# Altium schematic sheet DP_UART.SchDoc
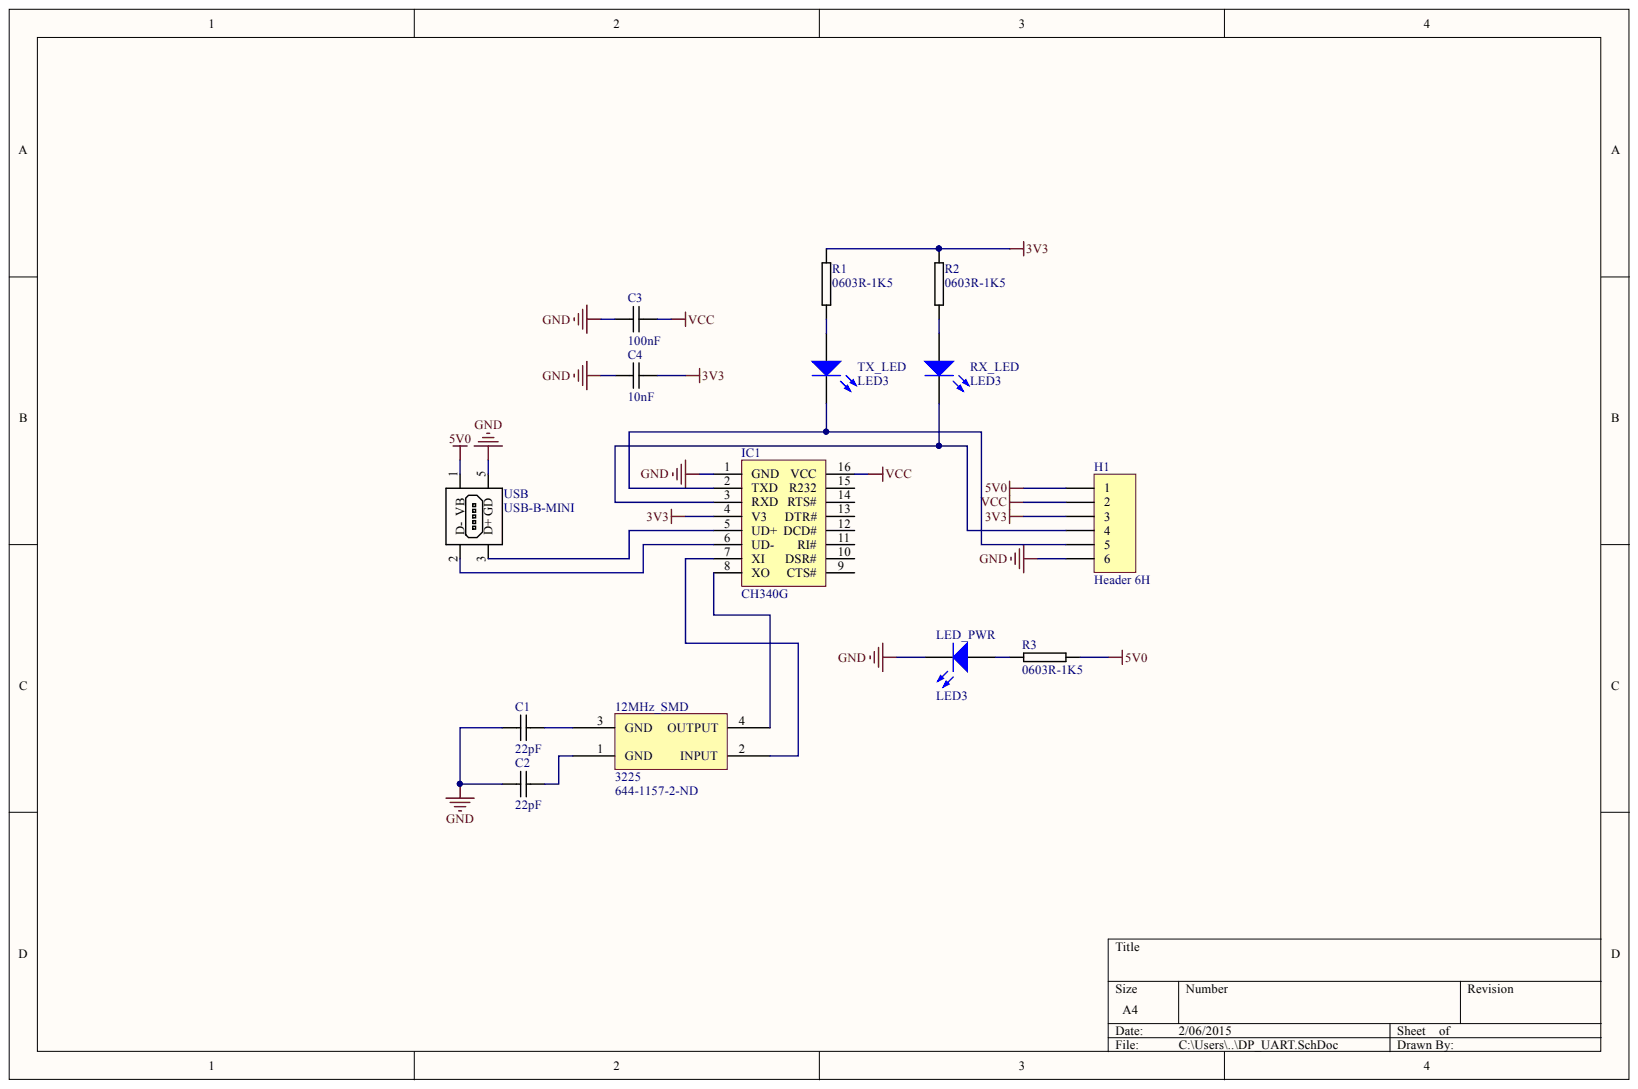
[diagram p0: DP_UART.SchDoc]

## Parts
12MHz_SMD  3225  Supplier Part Number 1: 644-1157-2-ND  [XTAL 3225]
C1  22pF  [0603C-GENERIC]
C2  22pF  [0603C-GENERIC]
C3  100nF  [0603C-GENERIC]
C4  10nF  [0603C-GENERIC]
H1  Header 6H  [HDR1X6H]
IC1  CH340G  [SO16]
LED_PWR  LED3  [3.5X2.8X1.9]
R1  0603R-1K5  [0603R-1k5]
R2  0603R-1K5  [0603R-1k5]
R3  0603R-1K5  [0603R-1k5]
RX_LED  LED3  [3.5X2.8X1.9]
TX_LED  LED3  [3.5X2.8X1.9]
USB  USB-B-MINI  [USB-MINI-B]

## Nets
3V3: C4.2 H1.3 IC1.4(V3) R1.1 R2.1
5V0: H1.1 R3.1 USB.1(VB)
GND: C1.1 C2.1 C3.1 C4.1 H1.6 IC1.1(GND) LED_PWR.2 USB.5(GD)
VCC: C3.2 H1.2 IC1.16(VCC)
Net12MHz_SMD_1: 12MHz_SMD.1(GND) C2.2
Net12MHz_SMD_2: 12MHz_SMD.2(INPUT) IC1.7(XI)
Net12MHz_SMD_3: 12MHz_SMD.3(GND) C1.2
Net12MHz_SMD_4: 12MHz_SMD.4(OUTPUT) IC1.8(XO)
NetH1_4: H1.4 IC1.3(RXD) RX_LED.2
NetH1_5: H1.5 IC1.2(TXD) TX_LED.2
NetIC1_5: IC1.5(UD+) USB.3(D+)
NetIC1_6: IC1.6(UD-) USB.2(D-)
NetLED_PWR_1: LED_PWR.1 R3.2
NetR1_2: R1.2 TX_LED.1
NetR2_2: R2.2 RX_LED.1

Not connected: IC1 9(CTS#) 10(DSR#) 11(RI#) 12(DCD#) 13(DTR#) 14(RTS#) 15(R232)

## Library data of the parts
12MHz_SMD = 3225 Quartz: Component Kind: Standard; Supplier 1: Digi-Key; Library Name: RVA_Reference_Crystal Oscillator_SMD.SchLib
C1 C2 = Passives_Capacitors_0603_22PF-50V-C0G-PAN: CAPACITOR 0603 CERAMIC; Manufacturer: Panasonic - ECG; Manufacturer Part Number: ECJ-1VC1H220J; Reel: C10; Temperature Coefficient: C0G, NP0; Tolerance: ±5%; Voltage - Rated: 50V; Capacitance: 22pF; Category: Capacitors; Component Kind: Standard; Features: General Purpose; Lead Spacing: -; Mounting Type: Surface Mount, MLCC; Operating Temperature: -55°C ~ 125°C; Package / Case: 0603 (1608 metric); Packaging: Tape & Reel (TR); RoHS: RoHS Compliant; Supplier: Digi-Key; Supplier 1: Digi-Key; Supplier Part Number: PCC220ACVTR-ND; Supplier Part Number 1: PCC220ACVTR-ND; Thickness: 0.80mm; Library Name: RVA_Passives_Capacitors_0603.SchLib
C3 = Passives_Capacitors_0603_100NF-25V-X7R-TDK: CAPACITOR 0603 CERAMIC; Manufacturer: TDK Corporation; Manufacturer Part Number: C1608X7R1E104K; Reel: C12; Component Kind: Standard; Supplier 1: Digi-Key; Supplier Part Number 1: 445-1316-2-ND; Library Name: RVA_Passives_Capacitors_0603.SchLib
C4 = Passives_Capacitors_0603_10NF-50V-X7R-MUR: CAPACITOR 0603 CERAMIC; Manufacturer: Murata Electronics North America; Manufacturer Part Number: GRM188R71H103KA01D; Reel: C22; Component Kind: Standard; Supplier 1: Digi-Key; Supplier Part Number 1: 490-1512-2-ND; Library Name: RVA_Passives_Capacitors_0603.SchLib
H1 = Header 6H: Header, 6-Pin, Right Angle; Component Kind: Standard; LatestRevisionDate: 17-Jul-2002; LatestRevisionNote: Re-released for DXP Platform.; Library Name: Miscellaneous Connectors.IntLib; Signal Integrity: Connector; Publisher: Altium Limited
LED_PWR RX_LED TX_LED = LED3: Typical BLUE SiC LED; Component Kind: Standard; DatasheetVersion: 16-Apr-2002; LatestRevisionDate: 17-Dec-2003; LatestRevisionNote: PCB Footprint replaced.; Library Name: Miscellaneous Devices.IntLib; PackageDescription: SM LED; 2 C-Bend Leads; Body 3.5 x 2.8 x 1.9 mm, inc leads (LxWxH typ); PackageReference: 3.5X2.8X1.9; PackageVersion: 16-Apr-2002; Published: 8-Jun-2000; Signal Integrity: LED; Simulation: LED3; Publisher: Altium Limited
R1-R3 = 0603R-1K5: GENERIC RESISTOR 0603; Manufacturer: Stackpole Electronics Inc; Manufacturer Part Number: RMCF 1/16 15K 1% R; Power (Watts): 0.1W, 1/10W; Resistance In Ohms: 15.0K; Temperature Coefficient: ±100ppm/°C; Tolerance: ±1%; Case: 0603 (1608 metric); Category: Resistors; Component Kind: Standard; Composition: Thick Film; Lead Style: Surface Mount (SMD - SMT); Packaging: Tape & Reel (TR); RoHS: RoHS Compliant; Supplier: Digi-Key; Supplier 1: Digi-Key; Supplier Part Number: RMCF1/1615KFRTR-ND; Supplier Part Number 1: RMCF1/1615KFRTR-ND; Library Name: RVA_Passives_Resistors_0603.SchLib
USB = Connector_USB_THT_USB-B-MINI: MINI USB B DEVICE CONNECTOR; Component Kind: Standard; Library Name: RVA_Connector_USB_THT.SchLib
IC1 = CH340G: Component Kind: Standard; Library Name: CH340.SchLib
